# Altium schematic sheet DM_imu-V1.0.SchDoc
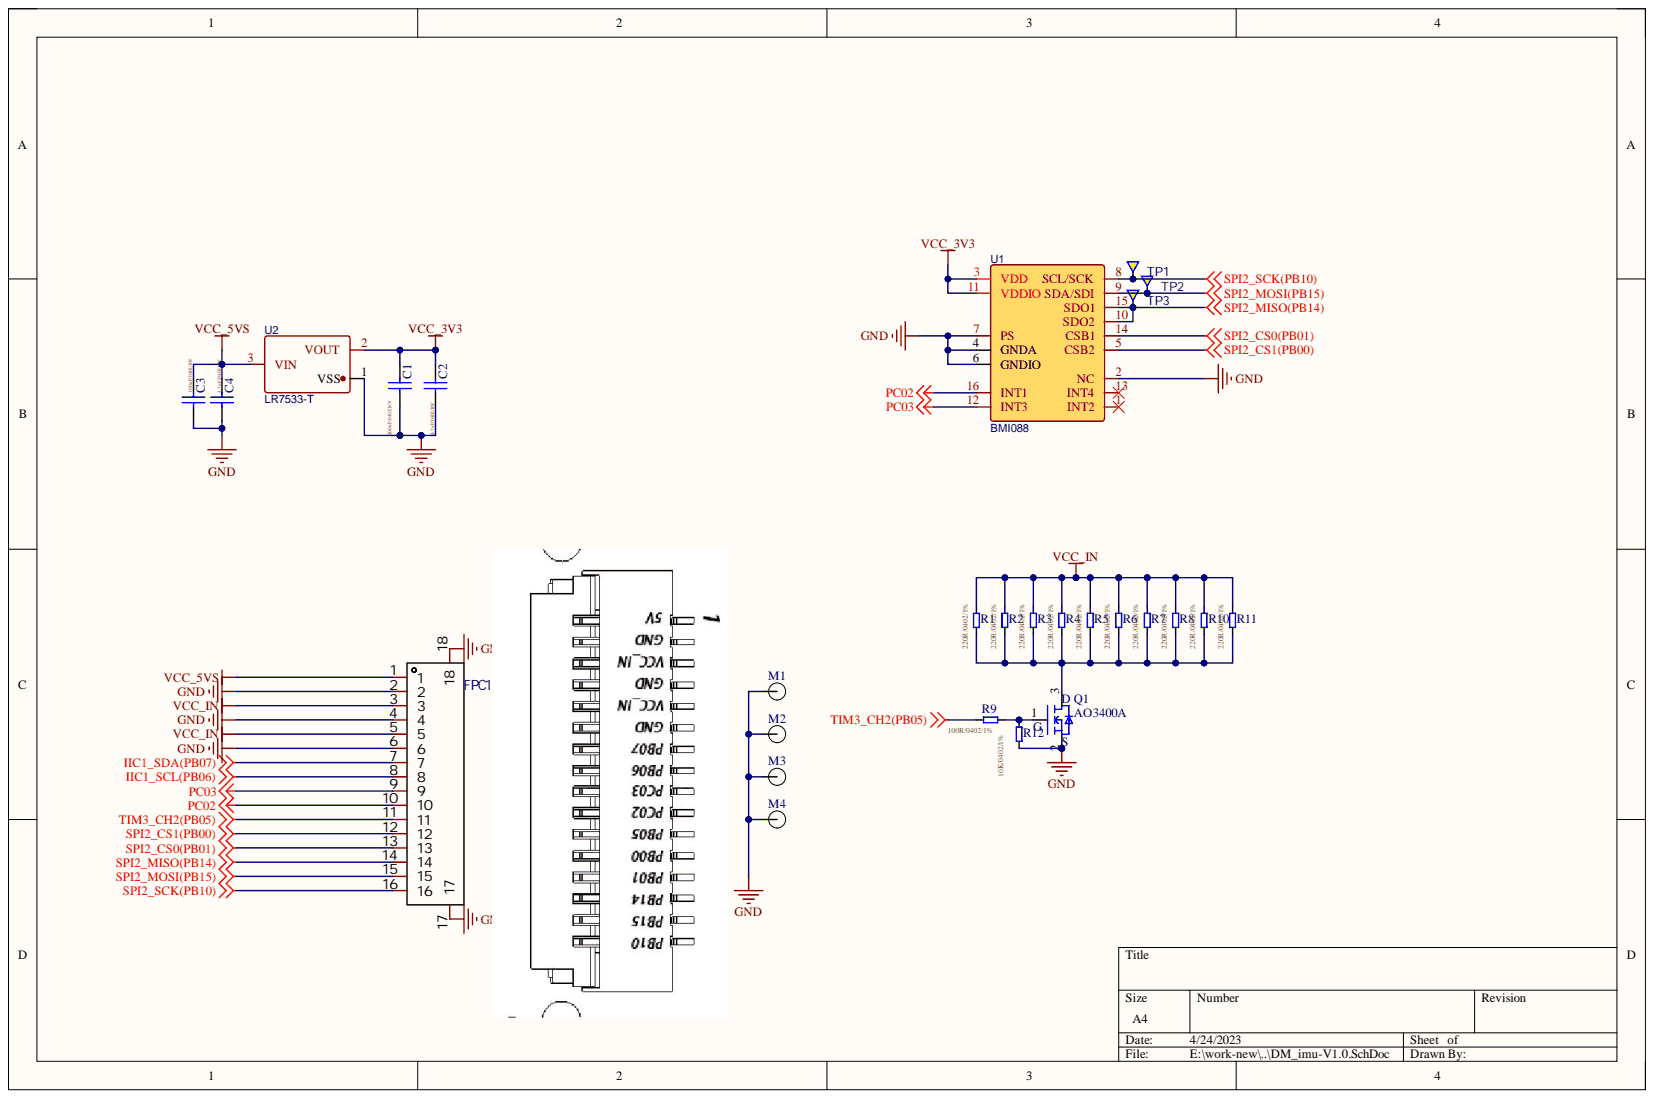
[diagram p0: DM_imu-V1.0.SchDoc]

## Parts
C1  100nF/0402/16V  [C0402]
C2  4.7uF/0402/10V  [C0402]
C3  100nF/0402/16V  [C0402]
C4  4.7uF/0402/10V  [C0402]
FPC1  THD0510-16CL-GF  [FPC-SMD_16P-P0.50_THD0510-16CL-GF]  (value not drawn)
M1  M2  [HOLE_PC2DC1P2]
M2  M2  [HOLE_PC2DC1P2]
M3  M2  [HOLE_PC2DC1P2]  (value not drawn)
M4  M2  [HOLE_PC2DC1P2]  (value not drawn)
Q1  AO3400A  [SOT-23]
R1  220R/0402/1%  [R0402]
R2  220R/0402/1%  [R0402]
R3  220R/0402/1%  [R0402]
R4  220R/0402/1%  [R0402]
R5  220R/0402/1%  [R0402]
R6  220R/0402/1%  [R0402]
R7  220R/0402/1%  [R0402]
R8  220R/0402/1%  [R0402]
R9  100R/0402/1%  [R0402]
R10  220R/0402/1%  [R0402]
R11  220R/0402/1%  [R0402]
R12  10K/0402/1%  [R0402]
TP1  Testpad  [TP-0.6]  (value not drawn)  (no BOM)
TP2  Testpad  [TP-0.6]  (value not drawn)  (no BOM)
TP3  Testpad  [TP-0.6]  (value not drawn)  (no BOM)
U1  BMI088  [LGA-16-L4.5-W3.0-P0.50_TL]
U2  LR7533-T  [SOT-23-3_L2.9-W1.6-P1.90-LS2.8-BR]

## Nets
GND: C1.1 C2.1 C3.1 C4.1 FPC1.2 FPC1.4 FPC1.6 FPC1.17 FPC1.18 M1.0 M2.0 M3.0 M4.0 Q1.2 R12.2 U1.2(NC) U1.4(GNDA) U1.7(PS) U2.1
IIC1_SCL(PB06): FPC1.8
IIC1_SDA(PB07): FPC1.7
PC02: FPC1.10 U1.16(INT1)
PC03: FPC1.9 U1.12(INT3)
SPI2_CS0(PB01): FPC1.13 U1.14(CSB1)
SPI2_CS1(PB00): FPC1.12 U1.5(CSB2)
SPI2_MISO(PB14): FPC1.14 TP3.1 U1.10(SDO2) U1.15(SDO1)
SPI2_MOSI(PB15): FPC1.15 TP2.1 U1.9(SDA/SDI)
SPI2_SCK(PB10): FPC1.16 TP1.1 U1.8(SCL/SCK)
TIM3_CH2(PB05): FPC1.11 R9.2
VCC_3V3: C1.2 C2.2 U1.3(VDD) U1.11(VDDIO) U2.2
VCC_5VS: C3.2 C4.2 FPC1.1 U2.3
VCC_IN: FPC1.3 FPC1.5 R1.2 R2.2 R3.2 R4.2 R5.2 R6.2 R7.2 R8.2 R10.2 R11.2
NetQ1_1: Q1.1 R9.1 R12.1
NetQ1_3: Q1.3 R1.1 R2.1 R3.1 R4.1 R5.1 R6.1 R7.1 R8.1 R10.1 R11.1

Not connected: U1 1(INT2) 6(GNDIO) 13(INT4)

## Library data of the parts
C1 C3 = Cap: Value: 100nF/0603/50V; Component Kind: Standard; Description: 100nF/0402/16V; LatestRevisionDate: 17-Jul-2002; LatestRevisionNote: Re-released for DXP Platform.; Library Name: Miscellaneous Devices.IntLib; PackageDescription: Radial Cap, Thru-Hole; 2 Leads; 0.3 in Pin Spacing; PackageReference: RAD-0.3; Published: 8-Jun-2000; Signal Integrity: Cap; Simulation: CAP; Publisher: Altium Limited
C2 = Cap: Capacitor; Value: 4.7uF/0603/50V; Component Kind: Standard; LatestRevisionDate: 17-Jul-2002; LatestRevisionNote: Re-released for DXP Platform.; Library Name: Miscellaneous Devices.IntLib; PackageDescription: Radial Cap, Thru-Hole; 2 Leads; 0.3 in Pin Spacing; PackageReference: RAD-0.3; Published: 8-Jun-2000; Signal Integrity: Cap; Simulation: CAP; Publisher: Altium Limited
C4 = Cap: Capacitor; Value: 1uF/0603/50V; Component Kind: Standard; LatestRevisionDate: 17-Jul-2002; LatestRevisionNote: Re-released for DXP Platform.; Library Name: Miscellaneous Devices.IntLib; PackageDescription: Radial Cap, Thru-Hole; 2 Leads; 0.3 in Pin Spacing; PackageReference: RAD-0.3; Published: 8-Jun-2000; Signal Integrity: Cap; Simulation: CAP; Publisher: Altium Limited
FPC1 = THD0510-16CL-GF: 间距:0.5mm;触点数量:16;锁定特性:翻盖式;安装类型:卧贴;触点类型:下接;; Manufacturer: THD(台华达); Add into BOM: yes; FFC, FCB Thickness: 0.3mm; Locking Feature: 翻盖式; Number of Contacts: 16; Pitch: 0.5mm; Component Kind: Standard; Contact Type: 下接; Convert to PCB: yes; Datasheet: https://atta.szlcsc.com/upload/public/pdf/source/20181023/C283123_7A04B28AC8136FA0A8B5B8F3F8A01031.pdf; Device: THD0510-16CL-GF; Height Above Board: 1mm; JLCPCB Part Class: Extended Part; LCSC Part Name: 0.5mm P数:16 翻盖式 下接; Library Name: dm-sch_library.SchLib; Manufacturer Part: THD0510-16CL-GF; Mounting Type: 卧贴; Name: THD0510-16CL-GF; PCB3D: FPC-SMD_16P-P0.50_L9.8-W3.8-H1.0-THD0510-16CL-GF; Supplier: LCSC; Supplier Footprint: SMD,P=0.5mm; Supplier Part: C283123; Symbol: THD0510-16CL-GF
M1-M4 = Hole-M2.5: Component Kind: Standard; Library Name: ROBO_V01_2015-DESKTOP-643COGG.SchLib
Q1 = AO3400A: AO3400A;SOT23; Component Kind: Standard; Library Name: dm-sch_library.SchLib
R1-R8 R10 R11 = R: Component Kind: Standard; Description: 220R/0402/1%; Library Name: ROBO_V01_2015.SchLib
R9 R12 = R: 220R/0402/1%; Component Kind: Standard; Library Name: ROBO_V01_2015.SchLib
TP1-TP3 = Testpad: Testpad, round, 1.5mm; PartNumber: TP-R-1.5; Library Name: jetson-nano-baseboard.SCHLIB
U1 = BMI088: BOM_Manufacturer: Bosch Sensortec; Contributor: LCSC; SMT Type: Extend; BOM_JLCPCB SMD: Yes; BOM_Manufacturer Part: BMI088; BOM_Supplier: LCSC; BOM_Supplier Part: C194919; Component Kind: Standard; Library Name: dm-sch_library.SchLib; spicePre: U; spiceSymbolName: BMI088
U2 = LR7533-T: BOM_Manufacturer: LR; Contributor: LCSC; BOM_JLCPCB Part Class: Extended Part; BOM_Manufacturer Part: LR7533-T; BOM_Supplier: LCSC; BOM_Supplier Part: C486069; Component Kind: Standard; Library Name: dm-sch_library.SchLib; spicePre: U; spiceSymbolName: LR7533-T
